# Altium schematic sheet 05_Pressure_and_LoadCell.SchDoc
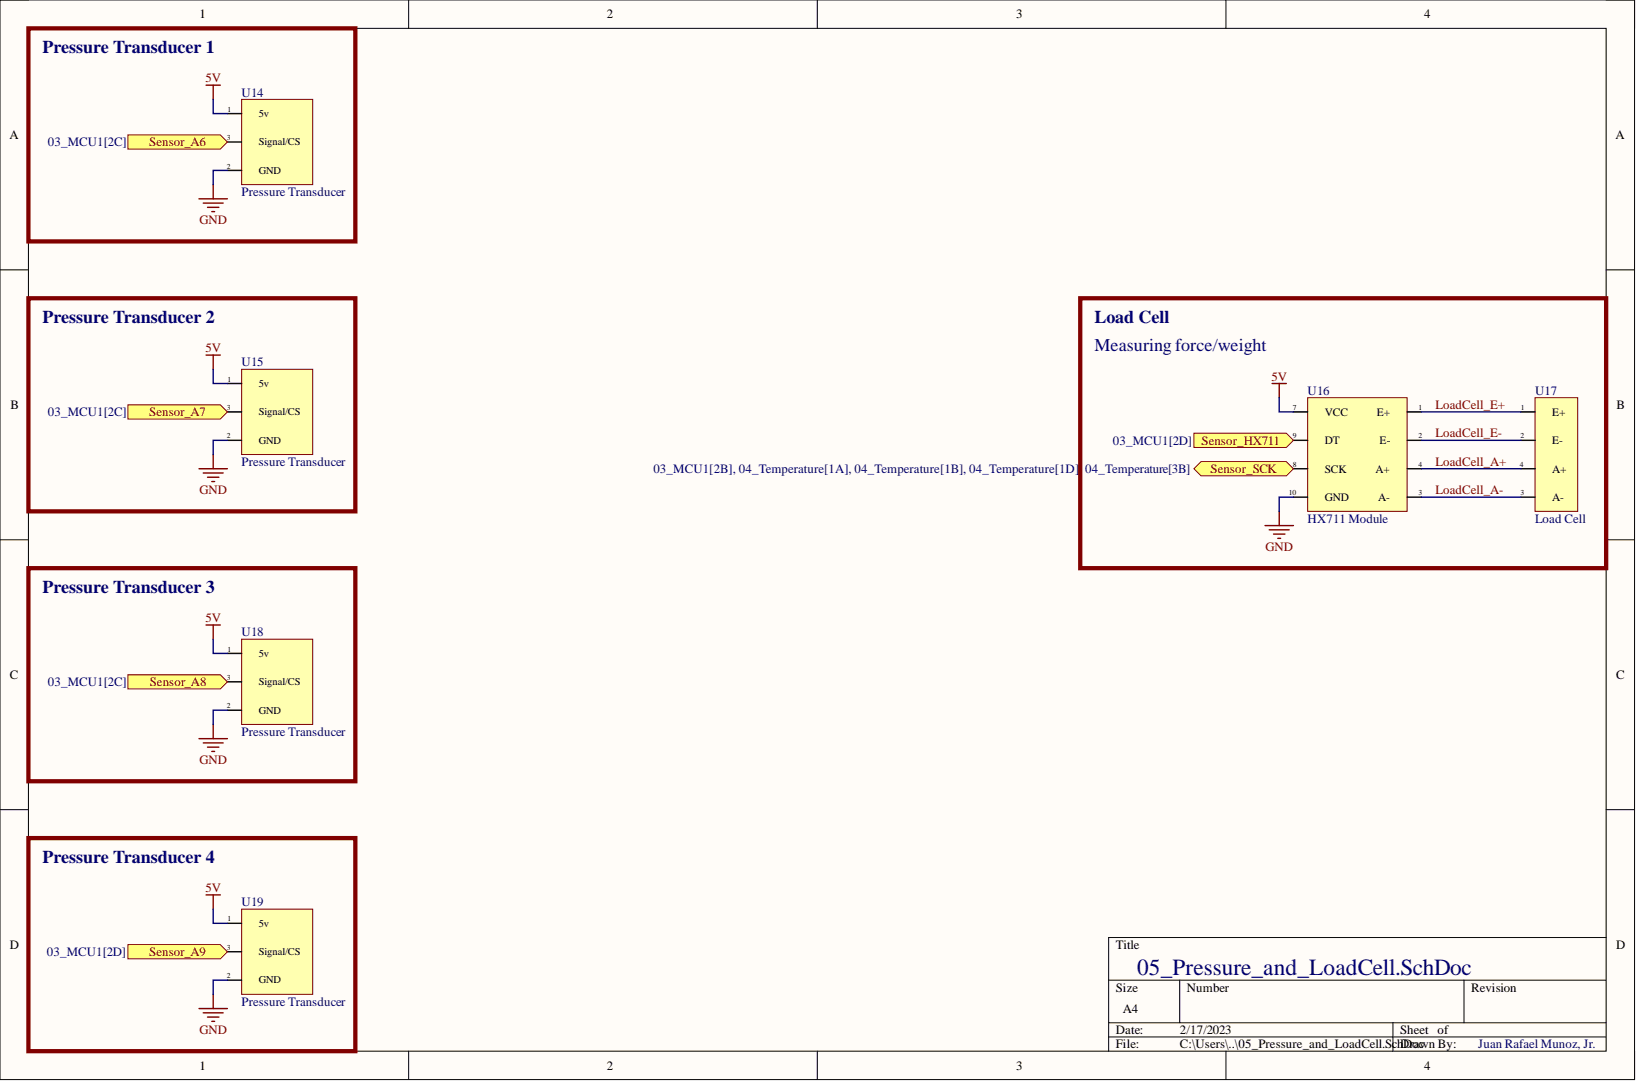
[diagram p0: 05_Pressure_and_LoadCell.SchDoc]

## Parts
U14  Pressure Transducer  [Pressure Sensor]
U15  Pressure Transducer  [Pressure Sensor]
U16  HX711 Module  [HX711 Module]
U17  Load Cell  [Cell Block]
U18  Pressure Transducer  [Pressure Sensor]
U19  Pressure Transducer  [Pressure Sensor]

## Ports
Sensor_A6, Sensor_A7, Sensor_A8, Sensor_A9, Sensor_HX711, Sensor_SCK

## Nets
5V: U14.1 U15.1 U16.7(VCC) U18.1 U19.1
GND: U14.2 U15.2 U16.10(GND) U18.2 U19.2
LoadCell_A+: U16.4(A+) U17.4(A+)
LoadCell_A-: U16.3(A-) U17.3(A-)
LoadCell_E+: U16.1(E+) U17.1(E+)
LoadCell_E-: U16.2(E-) U17.2(E-)
Sensor_A6: U14.3 ; port Sensor_A6
Sensor_A7: U15.3 ; port Sensor_A7
Sensor_A8: U18.3 ; port Sensor_A8
Sensor_A9: U19.3 ; port Sensor_A9
Sensor_HX711: U16.9(DT) ; port Sensor_HX711
Sensor_SCK: U16.8(SCK) ; port Sensor_SCK

## Library data of the parts
U14 U15 U18 U19 = Pressure Transducer: Component Kind: Standard; Library Name: Ground Support_Schlib.SchLib
U16 = HX711 Module: Component Kind: Standard; Library Name: Ground Support_Schlib.SchLib
U17 = Load Cell: Component Kind: Standard; Library Name: Ground Support_Schlib.SchLib
